# Altium schematic sheet RA_Local_Promotion_Board_5.SchDoc(RA_Local_Promotion_Board_5)
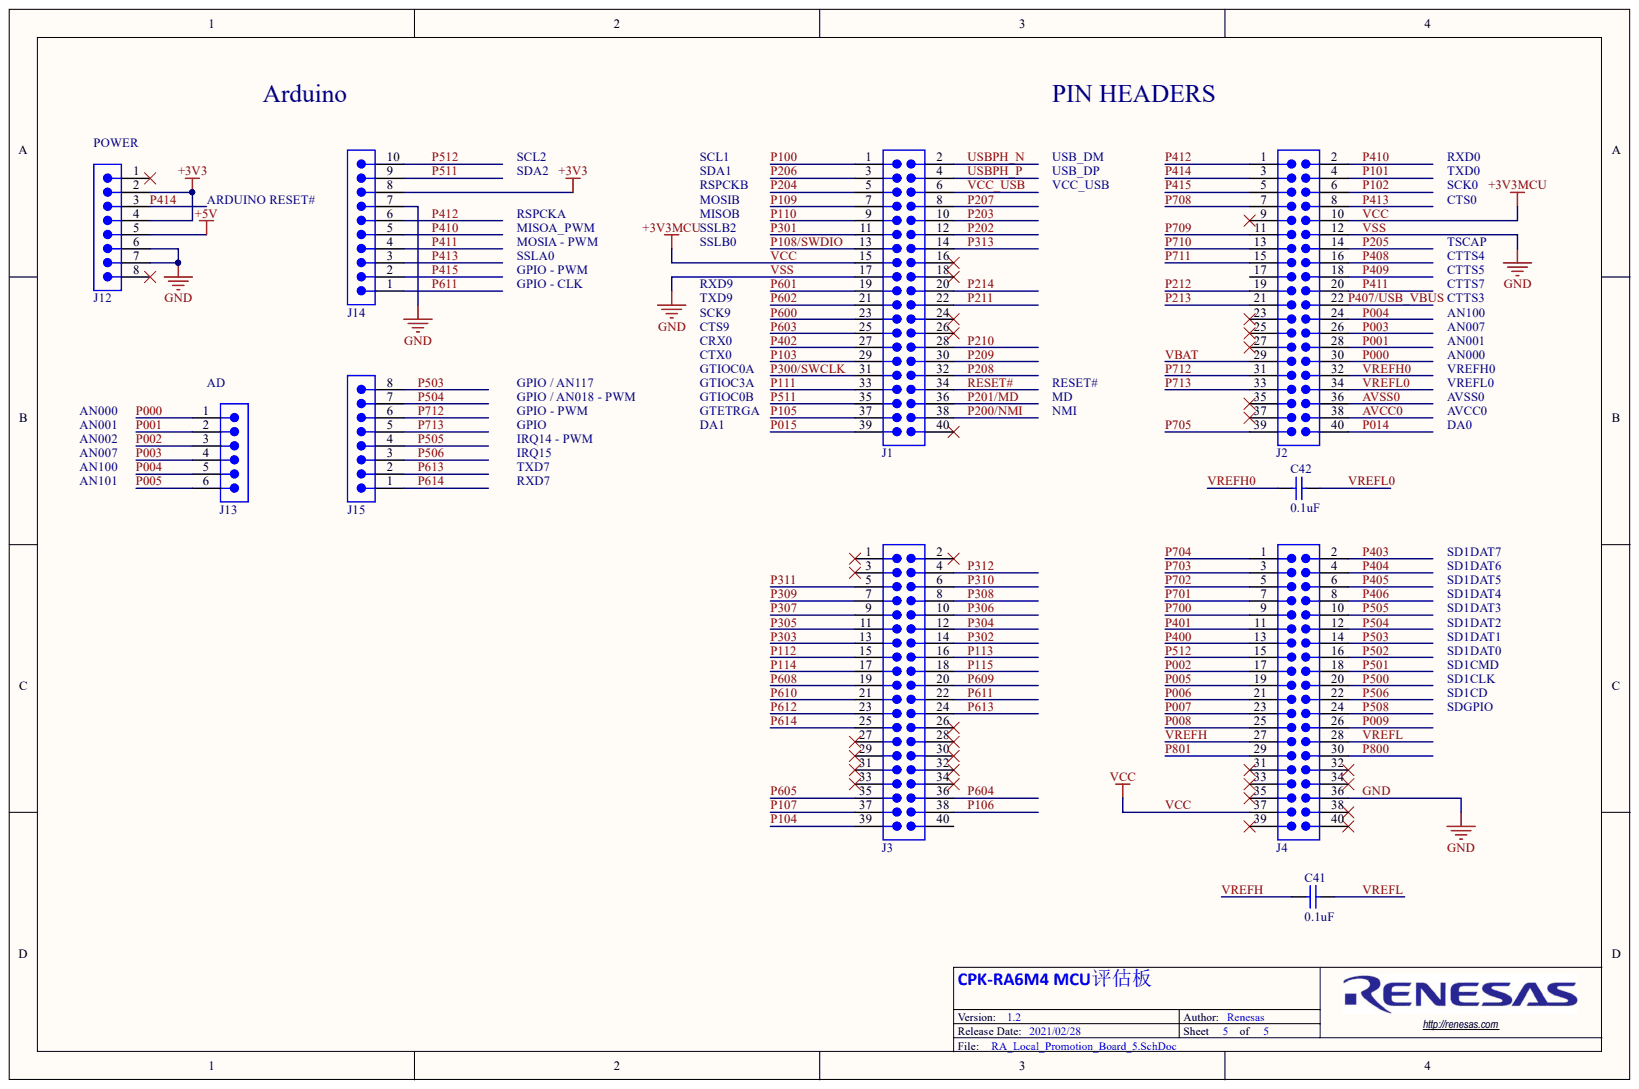
[diagram p0: RA_Local_Promotion_Board_5.SchDoc]

## Parts
C41  0.1uF  [CAPC0603-01]
C42  0.1uF  [CAPC0603-01]
J1  2x20  [SULLINS_PPPC202LFBN-RC]  (value not drawn)
J2  2x20  [SULLINS_PPPC202LFBN-RC]  (value not drawn)
J3  2x20  [SULLINS_PPPC202LFBN-RC]  (value not drawn)
J4  2x20  [SULLINS_PPPC202LFBN-RC]  (value not drawn)
J12  2.54mm 1x8  [FCI_77311-818-08LF]  (value not drawn)
J13  2.54mm 1x6  [FCI_77311-818-06LF]  (value not drawn)
J14  2.54mm 1x10  [FCI_77311-818-10LF]  (value not drawn)
J15  2.54mm 1x8  [FCI_77311-818-08LF]  (value not drawn)

## Nets
+3V3: J12.2 J12.4 J14.8
+5V: J12.5
AVCC0: J2.38
AVSS0: J2.36
GND: J1.17 J2.12 J4.36 J12.6 J12.7 J14.7
P000: J2.30 J13.1
P001: J2.28 J13.2
P002: J4.17 J13.3
P003: J2.26 J13.4
P004: J2.24 J13.5
P005: J4.19 J13.6
P006: J4.21
P007: J4.23
P008: J4.25
P009: J4.26
P014: J2.40
P015: J1.39
P100: J1.1
P101: J2.4
P102: J2.6
P103: J1.29
P104: J3.39
P105: J1.37
P106: J3.38
P107: J3.37
P108/SWDIO: J1.13
P109: J1.7
P110: J1.9
P111: J1.33
P112: J3.15
P113: J3.16
P114: J3.17
P115: J3.18
P200/NMI: J1.38
P201/MD: J1.36
P202: J1.12
P203: J1.10
P204: J1.5
P205: J2.14
P206: J1.3
P207: J1.8
P208: J1.32
P209: J1.30
P210: J1.28
P211: J1.22
P212: J2.19
P213: J2.21
P214: J1.20
P300/SWCLK: J1.31
P301: J1.11
P302: J3.14
P303: J3.13
P304: J3.12
P305: J3.11
P306: J3.10
P307: J3.9
P308: J3.8
P309: J3.7
P310: J3.6
P311: J3.5
P312: J3.4
P313: J1.14
P400: J4.13
P401: J4.11
P402: J1.27
P403: J4.2
P404: J4.4
P405: J4.6
P406: J4.8
P407/USB_VBUS: J2.22
P408: J2.16
P409: J2.18
P410: J2.2 J14.5
P411: J2.20 J14.4
P412: J2.1 J14.6
P413: J2.8 J14.3
P414: J2.3 J12.3
P415: J2.5 J14.2
P500: J4.20
P501: J4.18
P502: J4.16
P503: J4.14 J15.8
P504: J4.12 J15.7
P505: J4.10 J15.4
P506: J4.22 J15.3
P508: J4.24
P511: J1.35 J14.9
P512: J4.15 J14.10
P600: J1.23
P601: J1.19
P602: J1.21
P603: J1.25
P604: J3.36
P605: J3.35
P608: J3.19
P609: J3.20
P610: J3.21
P611: J3.22 J14.1
P612: J3.23
P613: J3.24 J15.2
P614: J3.25 J15.1
P700: J4.9
P701: J4.7
P702: J4.5
P703: J4.3
P704: J4.1
P705: J2.39
P708: J2.7
P709: J2.11
P710: J2.13
P711: J2.15
P712: J2.31 J15.6
P713: J2.33 J15.5
P800: J4.30
P801: J4.29
RESET#: J1.34
USBPH_N: J1.2
USBPH_P: J1.4
VBAT: J2.29
VCC: J1.15 J2.10 J4.37
VCC_USB: J1.6
VREFH: C41.1 J4.27
VREFH0: C42.1 J2.32
VREFL: C41.2 J4.28
VREFL0: C42.2 J2.34

Not connected: J1 16 18 24 26 40; J2 9 17 23 25 27 35 37; J3 1 2 3 26 27 28 29 30 31 32 33 34 40; J4 31 32 33 34 35 38 39 40; J12 1 8

## Library data of the parts
C41 C42 = CAP-0603-0.1uF: Ceramic Chip Capacitor 0603 0.1uF 50V; Manufacturer: MURATA; Mfg Part Number: GCM188L81H104KA57D; Dialectric: X8L; Tolerance: 10%; Capacitance: 0.1uF; Component Kind: Standard; Library Name: New Com.SchLib; Package: 0603; Voltage: 50V
J1-J4 = CON-2.54mm 2x20 Male: Header Male 2.54mm 40pos 2x20; Manufacturer: Sullins Connector Solutions; Mfg Part Number: PRPC020DAAN-RC; Positions: 40; Component Kind: Standard; Library Name: New Com.SchLib; Pitch: 2.54mm
J12 J15 = CON-2.54mm 1x8: FCI Header 0.1" pitch 8pos 1x8; Mfg Part Number: 77311-818-08LF; Positions: 8; Component Kind: Standard; Manufacturer: FCI; Pitch: 2.54mm; Library Name: Synergy.SchLib
J13 = CON-2.54mm 1x6: FCI Header 0.1" pitch 6pos 1x6; Mfg Part Number: 77311-818-06LF; Positions: 6; Component Kind: Standard; Manufacturer: FCI; Pitch: 2.54mm; Library Name: Synergy.SchLib
J14 = CON-2.54mm 1x10: FCI Header 0.1" pitch 10pos 1x10; Mfg Part Number: 77311-818-10LF; Positions: 10; Component Kind: Standard; Manufacturer: FCI; Pitch: 2.54mm; Library Name: Synergy.SchLib
